# Altium schematic sheet RS485.SchDoc
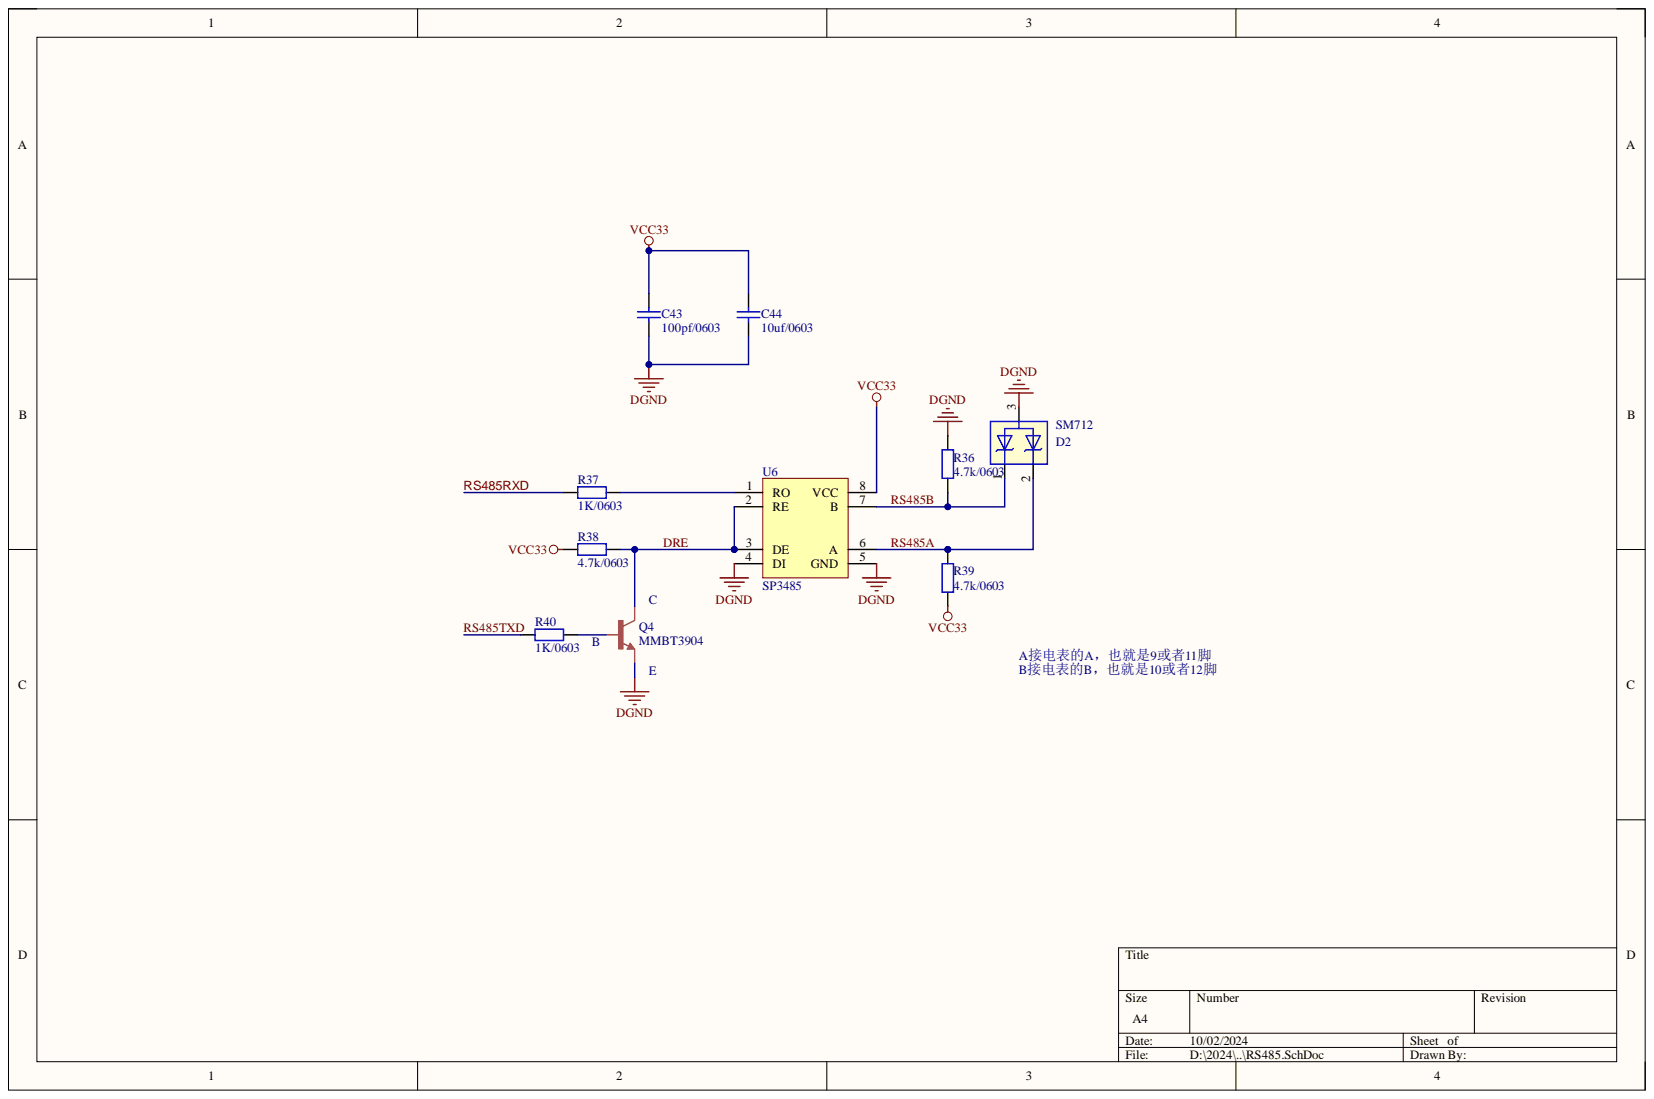
[diagram p0: RS485.SchDoc]

## Parts
C43  100pf/0603  [CAP0603]
C44  10uf/0603  [CAP0603]
D2  SM712  [SOT95P280X135-3N]
Q4  MMBT3904  [TRANS_SS8050-G]
R36  4.7k/0603  [RES0603]
R37  1K/0603  [RES0603]
R38  4.7k/0603  [RES0603]
R39  4.7k/0603  [RES0603]
R40  1K/0603  [RES0603]
U6  SP3485  [SP3485-SOP8]

## Nets
DGND: C43.1 C44.1 D2.3 Q4.2 R36.1 U6.4(DI) U6.5(GND)
VCC33: C43.2 C44.2 R38.1 R39.2 U6.8(VCC)
DRE: Q4.3 R38.2 U6.2(RE) U6.3(DE)
RS485A: D2.2 R39.1 U6.6(A)
RS485B: D2.1 R36.2 U6.7(B)
RS485RXD: R37.1
RS485TXD: R40.1
NetQ4_1: Q4.1 R40.2
NetR37_2: R37.2 U6.1(RO)

## Library data of the parts
C43 = Cap Semi: Capacitor (Semiconductor SIM Model); Value: 100pF; Component Kind: Standard; LatestRevisionDate: 29-May-2009; LatestRevisionNote: IPC-7351 Footprint Added.; Library Name: Miscellaneous Devices.IntLib; PackageDescription: Chip Capacitor; PackageReference: C1206; Published: 8-Jun-2000; Signal Integrity: Cap Semi; Simulation: CAP; Publisher: Altium Limited
C44 = Cap Semi: Capacitor (Semiconductor SIM Model); Value: 10uf; Component Kind: Standard; LatestRevisionDate: 29-May-2009; LatestRevisionNote: IPC-7351 Footprint Added.; Library Name: Miscellaneous Devices.IntLib; PackageDescription: Chip Capacitor; PackageReference: C1206; Published: 8-Jun-2000; Signal Integrity: Cap Semi; Simulation: CAP; Publisher: Altium Limited
D2 = SM05_D24: Part Number: 702112964; Design: 3; Origin: Altium; Component Kind: Standard; PCB Footprint: SOT95P280X135-3N
Q4 = SS8050-G: Component Kind: Standard; Library Name: ChargingPile.SchLib
R36-R40 = Res2: Resistor; Component Kind: Standard; LatestRevisionDate: 17-Jul-2002; LatestRevisionNote: Re-released for DXP Platform.; Library Name: Miscellaneous Devices.IntLib; PackageDescription: Axial Device, Thru-Hole; 2 Leads; 0.4 in Pin Spacing; PackageReference: AXIAL-0.4; Published: 8-Jun-2000; Signal Integrity: Res; Simulation: RESISTOR; Publisher: Altium Limited
U6 = SP3485: 485总线芯片; Component Kind: Standard; Library Name: fszhang.SchLib
